# Altium schematic sheet MCU.SchDoc
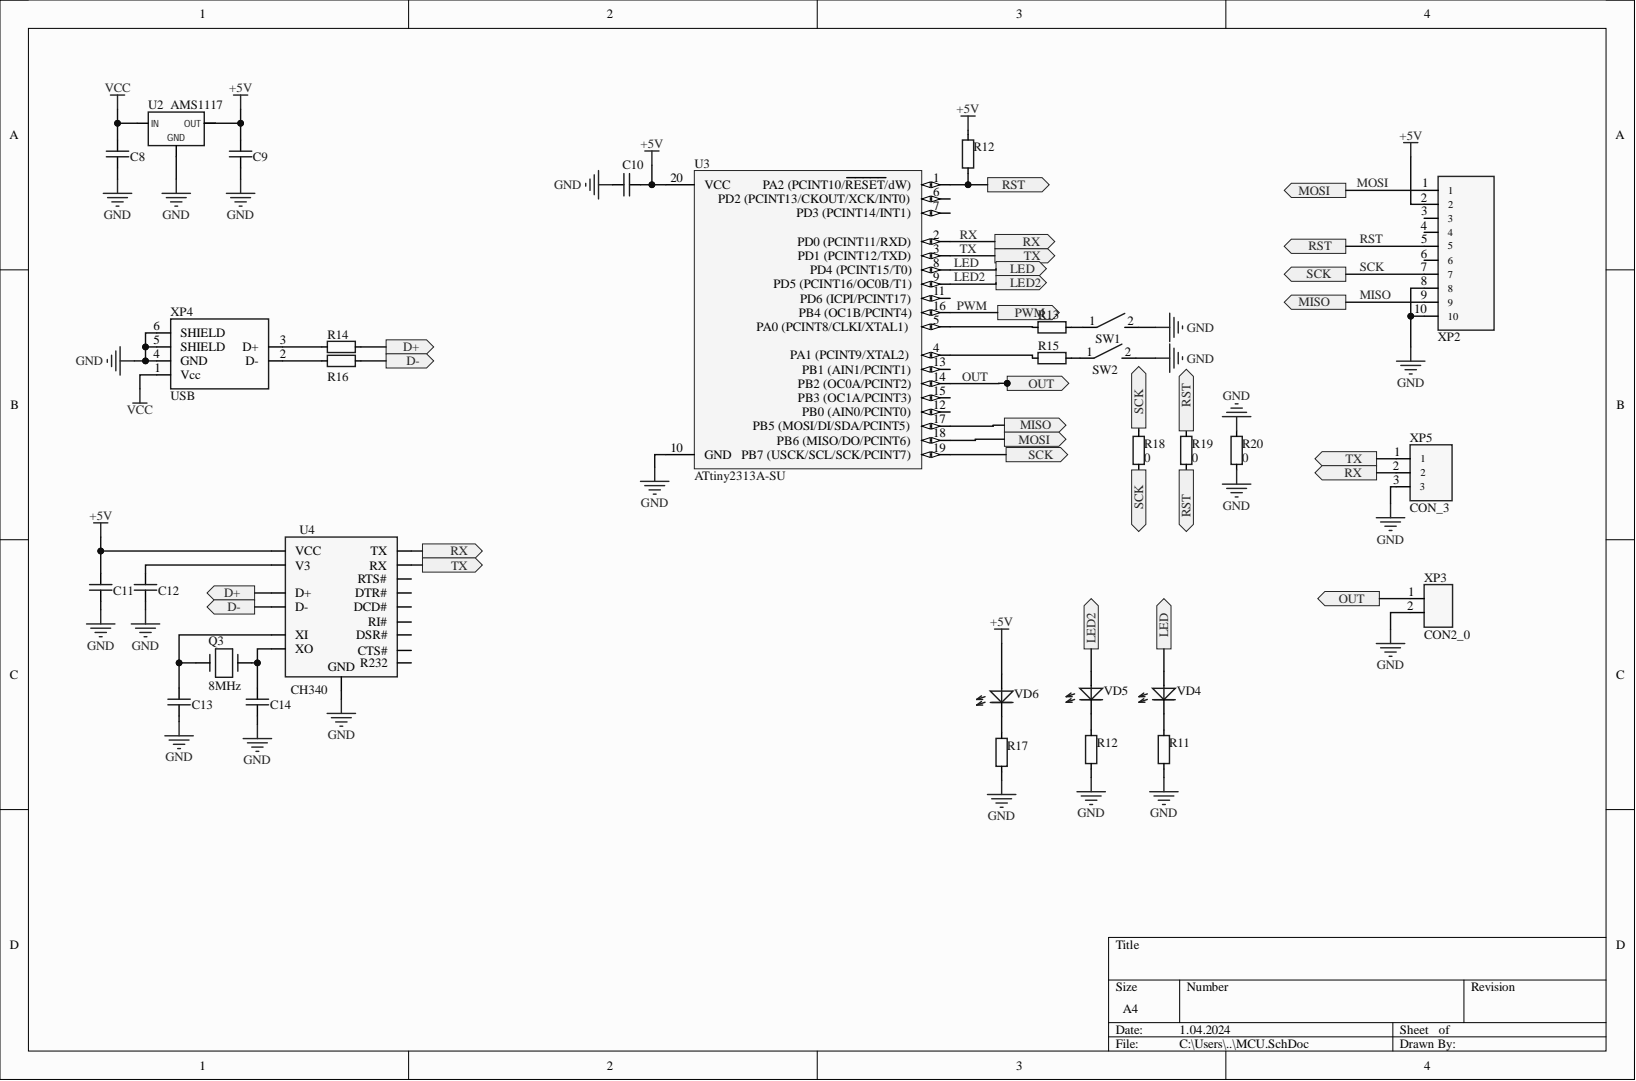
[diagram p0: MCU.SchDoc]

## Parts
C8  (no value)  [SMD_1206]
C9  (no value)  [SMD_1206]
C10  (no value)  [SMD_1206]
C11  (no value)  [SMD_0805]
C12  (no value)  [SMD_0805]
C13  (no value)  [SMD_0805]
C14  (no value)  [SMD_0805]
Q3  8MHz  [QUARTZ]
R11  (no value)  [SMD_0805]
R12  (no value)  [SMD_0805]
R12  (no value)  [SMD_0805]
R13  (no value)  [SMD_0805]
R14  (no value)  [SMD_0805]
R15  (no value)  [SMD_0805]
R16  (no value)  [SMD_0805]
R17  (no value)  [SMD_0805]
R18  0  [SMD_1206]
R19  0  [SMD_1206]
R20  0  [SMD_1206]
SW1  SW  [SWITCH]
SW2  SW  [SWITCH]
U2  AMS1117  [SOT-223]
U3  ATtiny2313A-SU  [SOP20-300mil]
U4  CH340  [SOIC-16]
VD4  (no value)  [LED-3mm]
VD5  (no value)  [LED-3mm]
VD6  (no value)  [LED-3mm]
XP2  CON_10  [BH10]  (value not drawn)
XP3  CON2_0  [HEAD-1/2/2.54]
XP4  USB  [USB/SM0.8-6H5]
XP5  CON_3  [HEAD-1/3/2.54]

## Ports
D+, D-, LED, LED2, MISO, MOSI, OUT, PWM, RST, RX, SCK, TX

## Nets
1: SW1.3 SW2.3
2: SW1.4 SW2.4
+5V: C9.1 C10.2 C11.2 R12.1 U2.2(OUT) U2.4 U3.20(VCC) U4.16(VCC) VD6.2 XP2.2
GND: C8.2 C9.2 C10.1 C11.1 C12.1 C13.1 C14.1 R11.2 R12.2 R17.2 R20.1 R20.2 SW1.2 SW2.2 U2.1 U3.10(GND) U4.1 XP2.8 XP2.10 XP3.2 XP4.4(GND) XP4.5(SHIELD) XP4.6(SHIELD) XP5.3
VCC: C8.1 U2.3(IN) XP4.1(Vcc)
LED: U3.8(PD4_(PCINT15/T0)) VD4.2 ; port LED
LED2: U3.9(PD5_(PCINT16/OC0B/T1)) VD5.2 ; port LED2
MISO: U3.17(PB5_(MOSI/DI/SDA/PCINT5)) XP2.9 ; port MISO
MOSI: U3.18(PB6_(MISO/DO/PCINT6)) XP2.1 ; port MOSI
OUT: U3.14(PB2_(OC0A/PCINT2)) XP3.1 ; port OUT
PWM: U3.16(PB4_(OC1B/PCINT4)) ; port PWM
RST: R12.2 R19.1 R19.2 U3.1 XP2.5 ; port RST
RX: U3.2(PD0_(PCINT11/RXD)) U4.2(TX) XP5.2 ; port RX
SCK: R18.1 R18.2 U3.19 XP2.7 ; port SCK
TX: U3.3(PD1_(PCINT12/TXD)) U4.3(RX) XP5.1 ; port TX
NetC12_2: C12.2 U4.4(V3)
NetC13_2: C13.2 Q3.1 U4.7(XI)
NetC14_2: C14.2 Q3.2 U4.8(XO)
NetR11_1: R11.1 VD4.1
NetR12_1: R12.1 VD5.1
NetR13_1: R13.1 SW1.1
NetR13_2: R13.2 U3.5(PA0_(PCINT8/CLKI/XTAL1))
NetR14_1: R14.1 U4.5(D+)
NetR14_2: R14.2 XP4.3(D+)
NetR15_1: R15.1 SW2.1
NetR15_2: R15.2 U3.4(PA1_(PCINT9/XTAL2))
NetR16_1: R16.1 U4.6(D-)
NetR16_2: R16.2 XP4.2(D-)
NetR17_1: R17.1 VD6.1

Not connected: U3 6(PD2_(PCINT13/CKOUT/XCK/INT0)) 7(PD3_(PCINT14/INT1)) 11(PD6_(ICPI/PCINT17)) 12(PB0_(AIN0/PCINT0)) 13(PB1_(AIN1/PCINT1)) 15(PB3_(OC1A/PCINT3)); U4 9(CTS#) 10(DSR#) 11(RI#) 12(DCD#) 13(DTR#) 14(RTS#) 15(R232); XP2 3 4 6

## Library data of the parts
C8-C14 = C_NONE_POLAR: None polar capacitor; Component Kind: Standard; Library Name: DISCRETE.SchLib
Q3 = CRYSTAL: Crystal oscillator; Component Kind: Standard; Library Name: DISCRETE.SchLib; Value: 8MHz
R11 R12 R12-R20 = RES: Resistor; Component Kind: Standard; Library Name: DISCRETE.SchLib
  R18-R20: Value: 0
SW1 SW2 = SW: Switch; Component Kind: Standard; Library Name: DISCRETE.SchLib
U2 = AMS1117: Linear voltage regulator; Component Kind: Standard; Library Name: ICs.SchLib
U3 = ATtiny2313A-SU: 8-bit AVR Microcontroller, 1.8-5.5V, 2KB Flash, 128 Bytes EEPROM, 128 Bytes SRAM, 20-pin SOIC, Industrial Grade (-40°C to 85°C), Pb-Free; Code_IPC: SOIC127P1030-20; Code_JEDEC: MS-013-AC; Component Kind: Standard; ComponentLink1Description: Manufacturer Link; ComponentLink1URL: http://www.atmel.com/products/avr/default.asp?; ComponentLink2Description: ATtiny2313A/4313 Datasheet; ComponentLink2URL: http://www.atmel.com/dyn/resources/prod_documents/doc8246.pdf; ComponentLink3Description: ATtiny2313A/4313 Summary; ComponentLink3URL: http://www.atmel.com/dyn/resources/prod_documents/8246S.pdf; ComponentLink4Description: Atmel.com Home; ComponentLink4URL: http://www.atmel.com; DatasheetVersion: revA, Dec-2009; Library Name: ICs.SchLib; PackageDescription: 20-lead, 0.300" Wide Body, Plastic Gull Wing Small Outline Package (SOIC); PackageReference: 20S; PackageVersion: revA, Jan-2002; Published: 1-Apr-2010; Supplier 1: Digi-Key; Supplier 2: Mouser; Supplier Part Number 1: ATTINY2313A-SU-ND; Supplier Part Number 2: 556-ATTINY2313A-SU; Publisher: Altium Limited
VD4-VD6 = LED: Component Kind: Standard; Description: LED; Library Name: DISCRETE.SchLib
U4 = CH340: Component Kind: Standard; Library Name: ICs.SchLib
XP2 = CON_10: Component Kind: Standard; Library Name: Connectors.SchLib
XP3 = CON2_0: Component Kind: Standard; Library Name: Connectors.SchLib
XP4 = USB: Component Kind: Standard; Library Name: Connectors.SchLib
XP5 = CON_3: Component Kind: Standard; Library Name: Connectors.SchLib
